# Altium schematic sheet SMPS.SchDoc
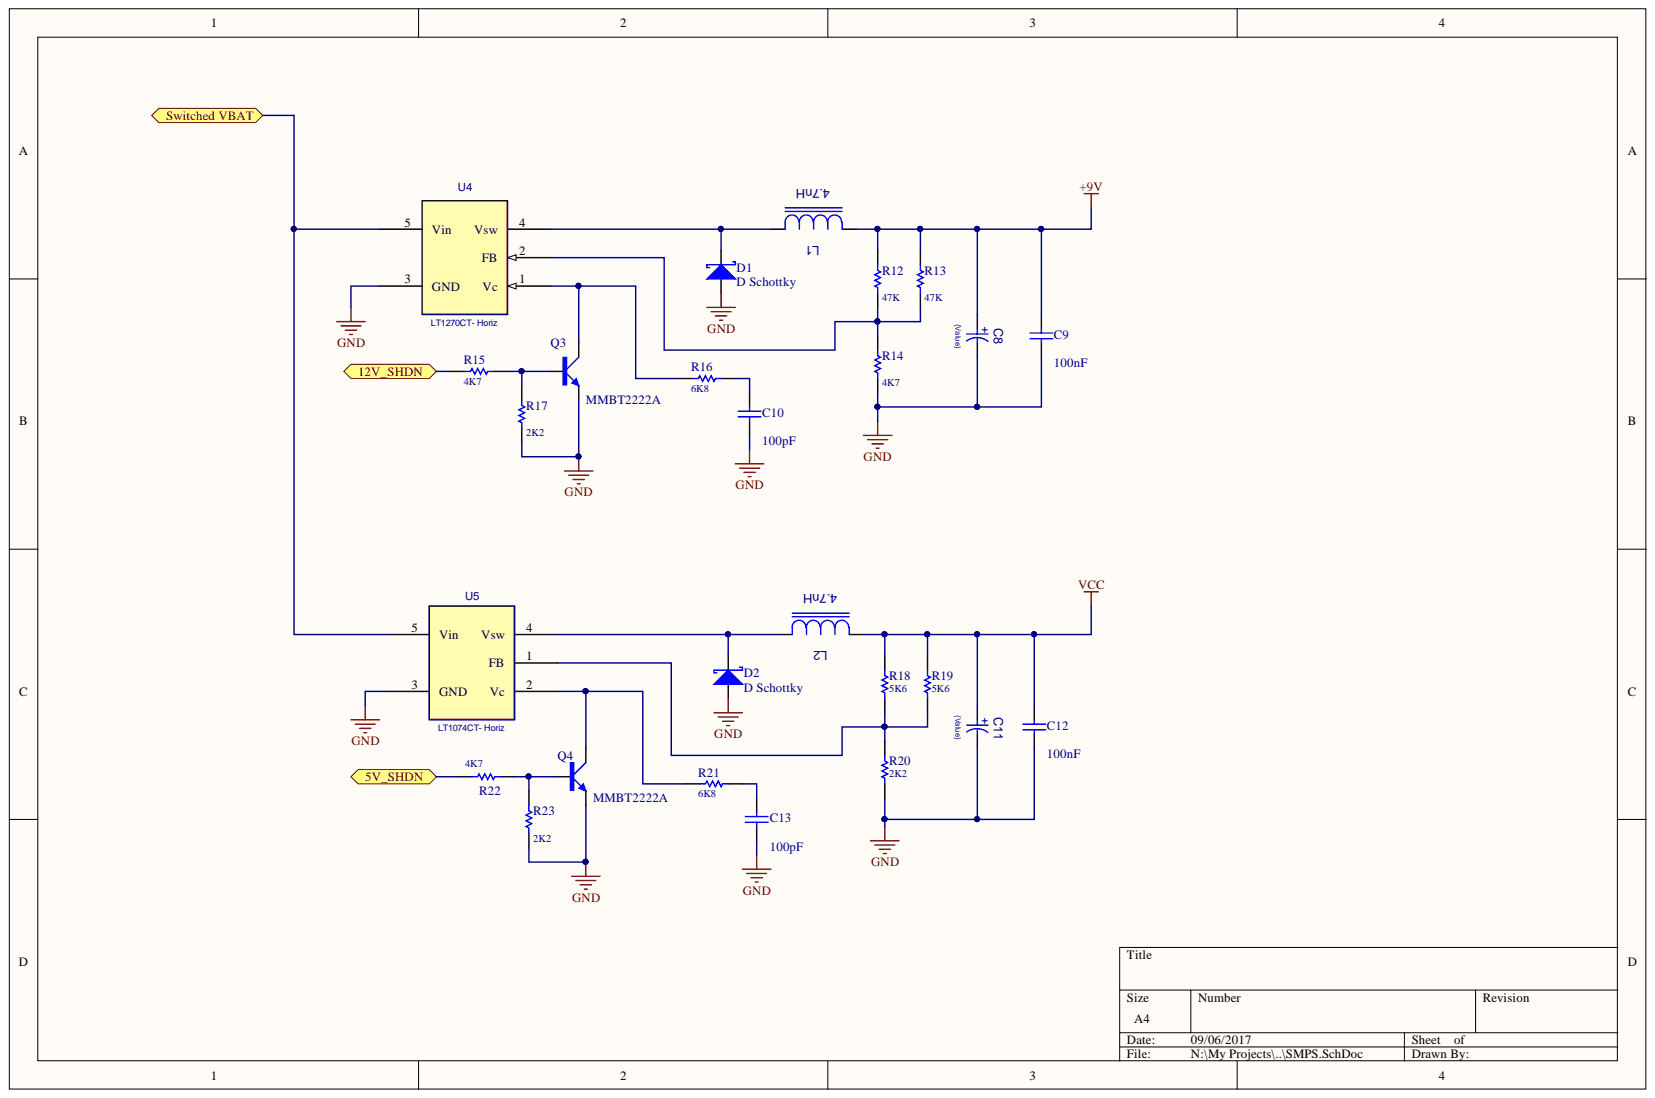
[diagram p0: SMPS.SchDoc]

## Parts
C8  value {Value}  [C-TANT#D]  (hidden comment: Cap Tantalum TH)
C9  value 100nF  [C-0805]  (hidden comment: Cap 0805)
C10  value 100pF  [Cap - Wima 60x250x700]  (hidden comment: Wima Cap)
C11  value {Value}  [C-TANT#D]  (hidden comment: Cap Tantalum TH)
C12  value 100nF  [C-0805]  (hidden comment: Cap 0805)
C13  value 100pF  [Cap - Wima 60x250x700]  (hidden comment: Wima Capacitor)
D1  D Schottky  [Shottkey TH]
D2  D Schottky  [Shottkey TH]
L1  value 4.7nH  [Coilcraft LP]  (hidden comment: INDUCTOR SMT Coilcraft)
L2  value 4.7nH  [Coilcraft LP]  (hidden comment: INDUCTOR SMT Coilcraft)
Q3  MMBT2222A  [SOT-23]
Q4  MMBT2222A  [SOT-23]
R12  value 47K  [R-0805 - 47K]  (hidden comment: Resistor - SMD 0805)
R13  value 47K  [R-0805 - 47K]  (hidden comment: Resistor - SMD 0805)
R14  value 4K7  [R-0805 -  4K7]  (hidden comment: Resistor - SMD 0805)
R15  value 4K7  [R-0805 -  4K7]  (hidden comment: Resistor - SMD 0805)
R16  value 6K8  [R-0805 - 6K8]  (hidden comment: Resistor - SMD 0805)
R17  value 2K2  [R-0805 - 2K2]  (hidden comment: Resistor - SMD 0805)
R18  value 5K6  [R-0805 - 5K6]  (hidden comment: Resistor - SMD 0805)
R19  value 5K6  [R-0805 - 5K6]  (hidden comment: Resistor - SMD 0805)
R20  value 2K2  [R-0805 - 2K2]  (hidden comment: Resistor - SMD 0805)
R21  value 6K8  [R-0805 - 6K8]  (hidden comment: Resistor - SMD 0805)
R22  value 4K7  [R-0805 -  4K7]  (hidden comment: Resistor - SMD 0805)
R23  value 2K2  [R-0805 - 2K2]  (hidden comment: Resistor - SMD 0805)
U4  LT1270CT- Horiz  [LT1270_TO-220-5_H]
U5  LT1074CT- Horiz  [LT1074_TO-220-5_H]

## Ports
5V_SHDN, 12V_SHDN, Switched VBAT

## Nets
+9V: C8.PLUS C9.2 L1.1 R12.2 R13.2
GND: C8.MINUS C9.1 C10.1 C11.MINUS C12.1 C13.1 D1.A D2.A Q3.2 Q4.2 R14.1 R17.1 R20.1 R23.1 U4.3(GND) U5.3(GND)
VCC: C11.PLUS C12.2 L2.1 R18.2 R19.2
5V_SHDN: R22.2 ; port 5V_SHDN
12V_SHDN: R15.2 ; port 12V_SHDN
Switched VBAT: U4.5(Vin) U5.5(Vin) ; port Switched VBAT
NetC10_2: C10.2 R16.2
NetC13_2: C13.2 R21.2
NetD1_K: D1.K L1.2 U4.4(Vsw)
NetD2_K: D2.K L2.2 U5.4(Vsw)
NetQ3_1: Q3.1 R15.1 R17.2
NetQ3_3: Q3.3 R16.1 U4.1(Vc)
NetQ4_1: Q4.1 R22.1 R23.2
NetQ4_3: Q4.3 R21.1 U5.2(Vc)
NetR12_1: R12.1 R13.1 R14.2 U4.2(FB)
NetR18_1: R18.1 R19.1 R20.2 U5.1(FB)

## Library data of the parts
C8 C11 = C-TANT#D: Tantalum Capacitor SMD; Component Kind: Standard; Library Name: MKM Capacitors.IntLib
C9 C12 = Cap 0805: Capacitor SMD; Component Kind: Standard; LatestRevisionDate: 17-Jul-2002; LatestRevisionNote: Re-released for DXP Platform.; PackageReference: C1206; Published: 8-Jun-2000; Library Name: MKM Capacitors.IntLib; Publisher: Altium Limited
C10 = Cap-Wima 60x250x700: Wima Capacitor; Component Kind: Standard; LatestRevisionDate: 17-Jul-2002; LatestRevisionNote: Re-released for DXP Platform.; PackageReference: C1206; Published: 8-Jun-2000; Library Name: MKM Capacitors.IntLib; Publisher: Altium Limited
C13 = Cap-Wima 60x250x700: Component Kind: Standard; Description: Wima Capacitor; LatestRevisionDate: 17-Jul-2002; LatestRevisionNote: Re-released for DXP Platform.; PackageReference: C1206; Published: 8-Jun-2000; Library Name: MKM Capacitors.IntLib; Publisher: Altium Limited
D1 D2 = D Schottky: Schottky Diode; Code_JEDEC: DO-214-AA; Component Kind: Standard; LatestRevisionDate: 17-Jul-2002; LatestRevisionNote: Re-released for DXP Platform.; PackageReference: SMB; Published: 8-Jun-2000; Signal Integrity: D Schottky; Simulation: SKYDIODE; Library Name: MKM Diodes.IntLib; Publisher: Altium Limited
L1 L2 = INDUCTOR SMT Coilcraft: INDUCTOR-100uH SMT; Component Kind: Standard; Library Name: MKM Inductance_transformers.IntLib
Q3 Q4 = MMBT2222A: NPN Switching Transistor; Component Kind: Standard; ComponentLink1Description: Manufacturer Link; ComponentLink1URL: http://www.semiconductors.philips.com/; ComponentLink2Description: Datasheet; ComponentLink2URL: http://www.semiconductors.philips.com/acrobat/datasheets/MMBT2222A_2.pdf; DatasheetVersion: 11-Apr-2000; LatestRevisionDate: 12-Dec-2006; LatestRevisionNote: IPC-7351 Footprint Added.; PackageDescription: 3-Pin SOT-23 Package 0.95 mm Pitch, 2.3 mm Lead Span; PackageReference: SOT23; PackageVersion: May-1999; Published: 17-Sep-2003; Signal Integrity: MMBT2222A; Simulation: QMMBT2222A; Library Name: MKM Transistors.IntLib; Publisher: Altium Limited
R12 R13 = R0805- 47K: Component Kind: Standard; Description: Resistor - SMD 0805; LatestRevisionDate: 17-Jul-2002; LatestRevisionNote: Re-released for DXP Platform.; PackageReference: AXIAL-0.3; Published: 8-Jun-2000; Signal Integrity: Res; Simulation: RESISTOR; Library Name: MKM Resistors.IntLib; Publisher: Altium Limited
R14 R15 R22 = R0805- 4K7: Component Kind: Standard; Description: Resistor - SMD 0805; LatestRevisionDate: 17-Jul-2002; LatestRevisionNote: Re-released for DXP Platform.; PackageReference: AXIAL-0.3; Published: 8-Jun-2000; Signal Integrity: Res; Simulation: RESISTOR; Library Name: MKM Resistors.IntLib; Publisher: Altium Limited
R16 R21 = R0805- 6K8: Component Kind: Standard; Description: Resistor - SMD 0805; LatestRevisionDate: 17-Jul-2002; LatestRevisionNote: Re-released for DXP Platform.; PackageReference: AXIAL-0.3; Published: 8-Jun-2000; Signal Integrity: Res; Simulation: RESISTOR; Library Name: MKM Resistors.IntLib; Publisher: Altium Limited
R17 R20 R23 = R0805- 2K2: Component Kind: Standard; Description: Resistor - SMD 0805; LatestRevisionDate: 17-Jul-2002; LatestRevisionNote: Re-released for DXP Platform.; PackageReference: AXIAL-0.3; Published: 8-Jun-2000; Signal Integrity: Res; Simulation: RESISTOR; Library Name: MKM Resistors.IntLib; Publisher: Altium Limited
R18 R19 = R0805- 5K6: Component Kind: Standard; Description: Resistor - SMD 0805; LatestRevisionDate: 17-Jul-2002; LatestRevisionNote: Re-released for DXP Platform.; PackageReference: AXIAL-0.3; Published: 8-Jun-2000; Signal Integrity: Res; Simulation: RESISTOR; Library Name: MKM Resistors.IntLib; Publisher: Altium Limited
U4 = LT1270CT- Horiz: Step-down Switching Regulator; CH: WL-07-2004; CR: WL; Vendor: Linear; ComponentHeight: 25mm; Component Kind: Standard; Vendor P/N: LT1270CT; Library Name: MKM Voltage regulators.IntLib
U5 = LT1074CT- Horiz: LT1074CT; Component Kind: Standard; Library Name: MKM Voltage regulators.IntLib
